# Altium schematic sheet PowerPath.SchDoc
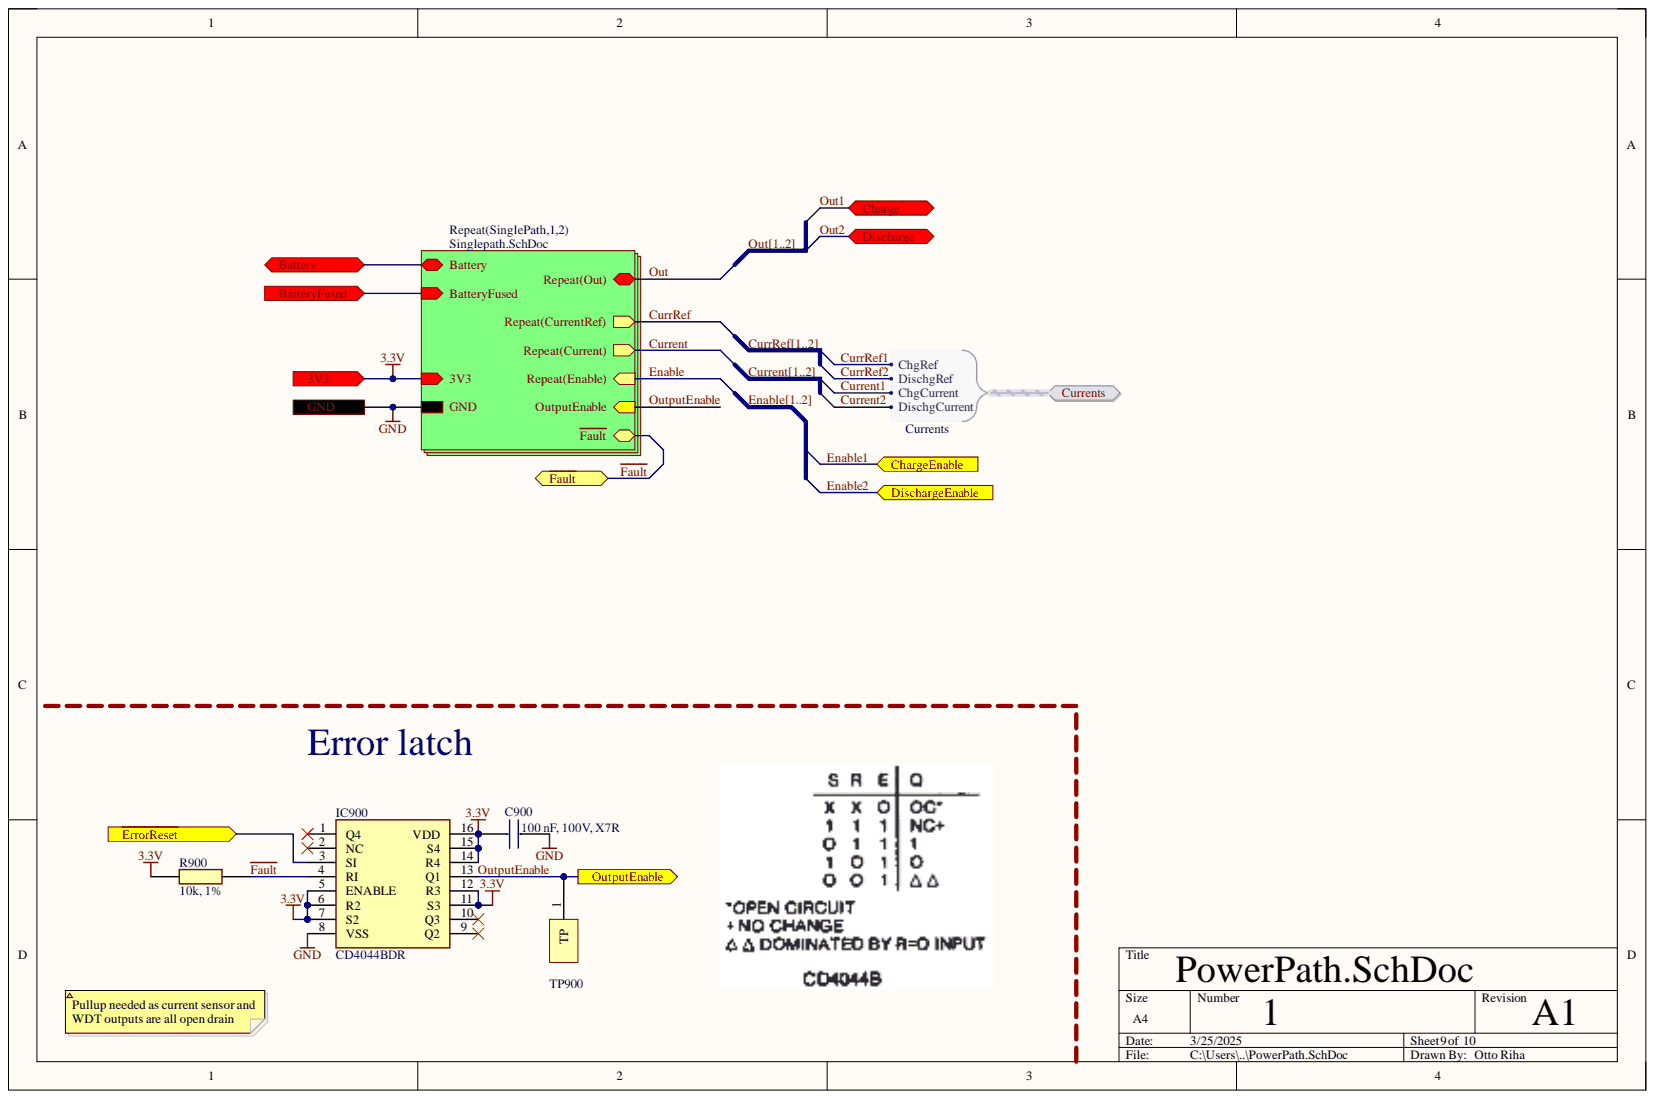
[diagram p0: PowerPath.SchDoc]

## Parts
C900  100 nF, 100V, X7R  [MAJx16]
IC900  CD4044BDR  [SOIC127P600X175-16N]
R900  value 10k, 1%  [RESC1608X50N]  (hidden comment: CRCW060310K0FKEI)
TP900  Test Pad 1mm  [Test Pad 1mm-Footprint-1]  (value not drawn)

## Ports
3V3, Battery, BatteryFused, Charge, ChargeEnable, Currents, Currents.ChgCurrent, Currents.ChgRef, Currents.DischgCurrent, Currents.DischgRef, Discharge, DischargeEnable, GND, OutputEnable, ~{ErrorReset}, ~{Fault}

## Nets
3.3V: C900.1 IC900.5(ENABLE) IC900.6(R2) IC900.7(S2) IC900.11(S3) IC900.12(R3) IC900.14(R4) IC900.15(S4) IC900.16(VDD) R900.1 ; port 3V3
~{ErrorReset}: IC900.3(SI) ; port ~{ErrorReset}
~{Fault}: IC900.4(RI) R900.2 ; port ~{Fault}
GND: C900.2 IC900.8(VSS) ; port GND
OutputEnable: IC900.13(Q1) TP900.1 ; port OutputEnable
NetIC900_1: IC900.1(Q4)
NetIC900_2: IC900.2(NC)
NetIC900_9: IC900.9(Q2)
NetIC900_10: IC900.10(Q3)
Battery: ; port Battery
BatteryFused: ; port BatteryFused
Current1: ; port Currents  [also labelled Current]
Current2: ; port Currents  [also labelled Current]
CurrRef1: ; port Currents  [also labelled CurrRef]
CurrRef2: ; port Currents  [also labelled CurrRef]
Enable1: ; port ChargeEnable  [also labelled Enable]
Enable2: ; port DischargeEnable  [also labelled Enable]
Out1: ; port Charge  [also labelled Out]
Out2: ; port Discharge  [also labelled Out]

## Library data of the parts
C900 = MAJCH168BB7104KTEA01: Capacitor 100nF, 100V, X7R; Manufacturer_Name: TAIYO YUDEN; Arrow Part Number: MAJCH168BB7104KTEA01; Arrow Price/Stock: https://www.arrow.com/en/products/majch168bb7104ktea01/taiyo-yuden?utm_currency=USD&region=nac; Component Kind: Standard; Datasheet Link: https://ds.yuden.co.jp/TYCOMPAS/or/detail?pn=MAJCH168BB7104KTEA01; Height: 0.8mm; Library Name: SamacSys.SchLib; Manufacturer_Part_Number: MAJCH168BB7104KTEA01; Mouser Part Number: 963-MAJCH168BB7104KT; Mouser Price/Stock: https://www.mouser.co.uk/ProductDetail/TAIYO-YUDEN/MAJCH168BB7104KTEA01?qs=ZcfC38r4PovaTBrG0IdezQ%3D%3D; Supplier 1: Mouser; Supplier Part Number 1: 963-MAJCH168BB7104KT
IC900 = CD4044BDR: Integrated Circuit; Manufacturer_Name: Texas Instruments; Arrow Part Number: CD4044BDR; Arrow Price/Stock: https://www.arrow.com/en/products/cd4044bdr/texas-instruments?region=nac; Component Kind: Standard; Datasheet Link: http://www.ti.com/lit/ds/symlink/cd4043b.pdf; Height: 1.75mm; Library Name: SamacSys.SchLib; Manufacturer_Part_Number: CD4044BDR; Mouser Part Number: 595-CD4044BDR; Mouser Price/Stock: https://www.mouser.co.uk/ProductDetail/Texas-Instruments/CD4044BDR?qs=LSR%252BNeaw%2FwCYur1174DbLw%3D%3D
R900 = CRCW060310K0FKEI: Resistor 10k, 1%; Manufacturer_Name: Vishay; Arrow Part Number: CRCW060310K0FKEI; Arrow Price/Stock: https://www.arrow.com/en/products/crcw060310k0fkei/vishay?utm_currency=USD&region=nac; Component Kind: Standard; Datasheet Link: http://www.vishay.com/docs/20035/dcrcwe3.pdf; Height: 0.5mm; Library Name: SamacSys.SchLib; Manufacturer_Part_Number: CRCW060310K0FKEI; Mouser Part Number: 71-CRCW060310K0FKEI; Mouser Price/Stock: https://www.mouser.co.uk/ProductDetail/Vishay-Dale/CRCW060310K0FKEI?qs=3qWEzBg5KnKofE%252B8acPBRA%3D%3D; Supplier 1: Mouser; Supplier Part Number 1: 71-CRCW060310K0FKEI
TP900 = CMP-002-00031-3: 1mm radius circuilar test pad; Component Kind: Standard; Library Name: EPFL Racing Team
